# Altium schematic sheet Real Time Clock.SchDoc
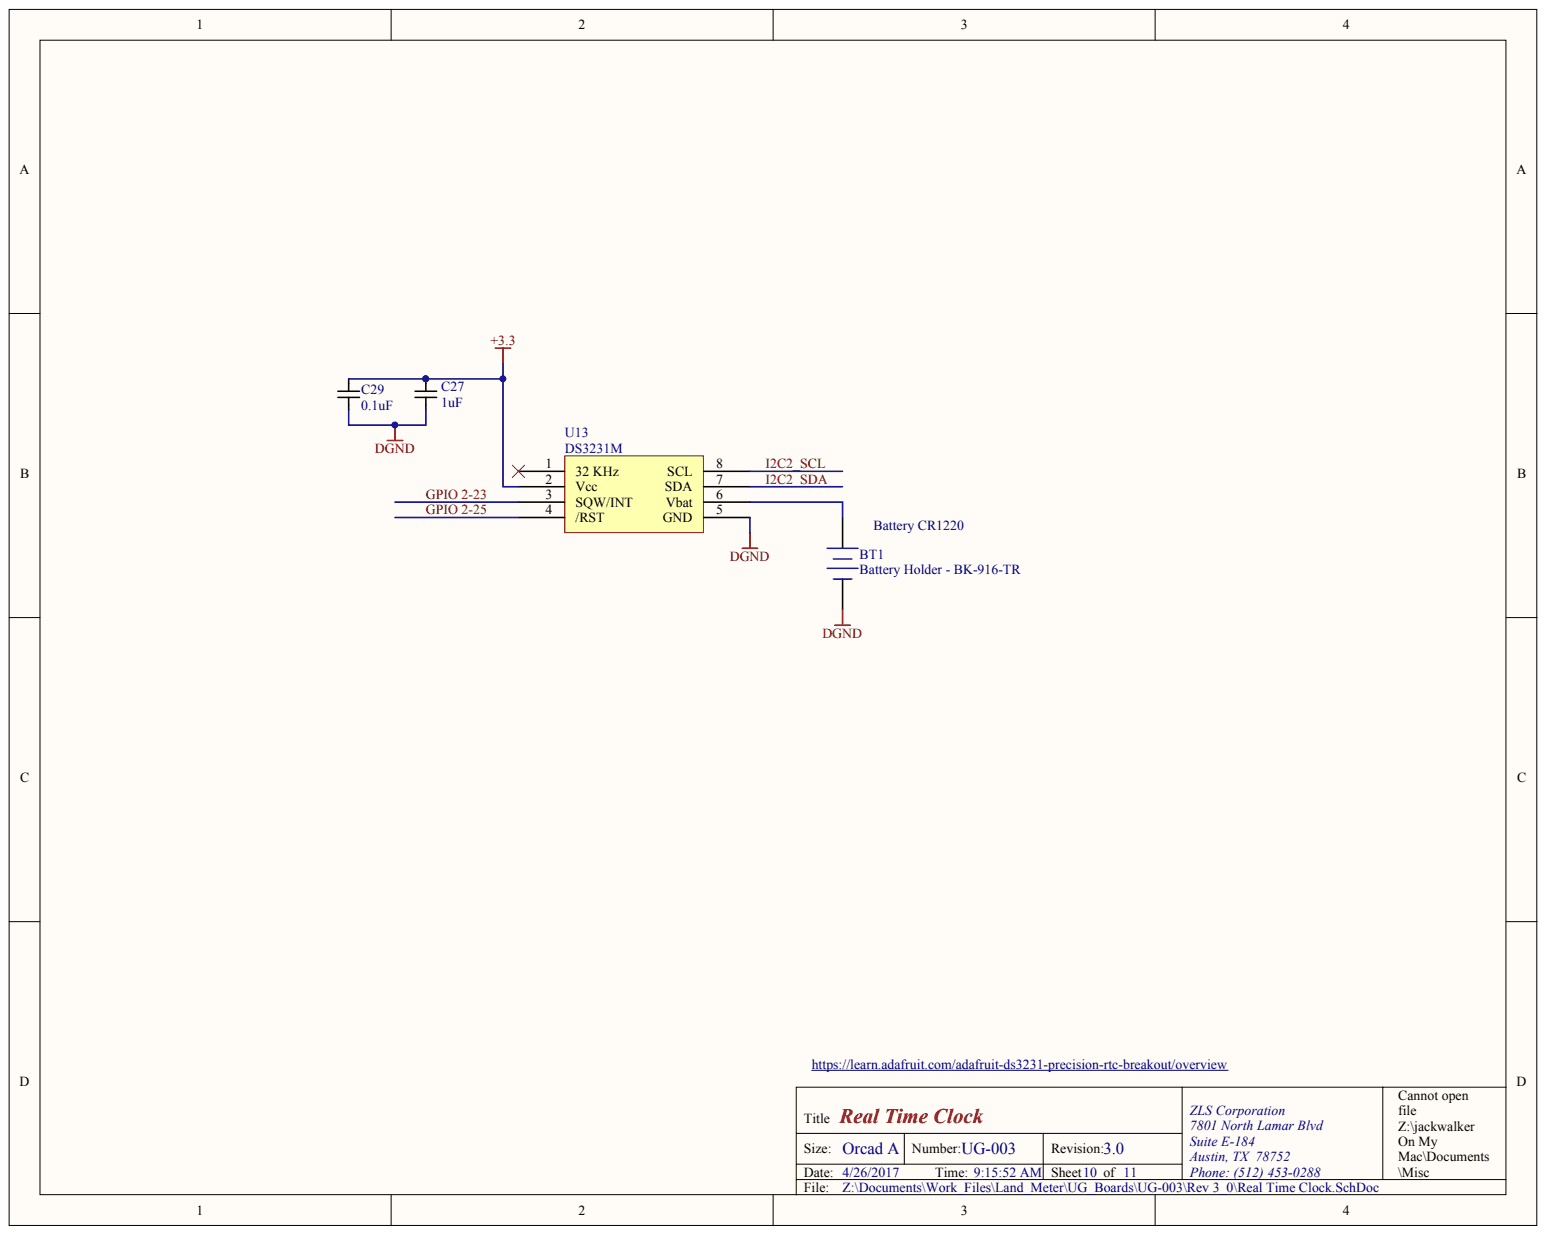
[diagram p0: Real Time Clock.SchDoc]

## Parts
BT1  Battery Holder - BK-916-TR
C27  1uF
C29  0.1uF
U13  DS3231M

## Nets
+3.3: C27.1 C29.1 U13.2(Vcc)
DGND: BT1.2 C27.2 C29.2 U13.5(GND)
GPIO 2-23: U13.3(SQW/INT)
GPIO 2-25: U13.4(/RST)
I2C2_SCL: U13.8(SCL)
I2C2_SDA: U13.7(SDA)
NetBT1_1: BT1.1 U13.6(Vbat)

Not connected: U13 1(32_KHz)

## Library data of the parts
BT1 = (no symbol name): Manufacturer 1: MPD (Memory Protection Devices); Description 1: CR1220, 1225 BATTERY RETAINER W/; Category 1: Battery Products; Battery Type, Function: Coin Cell, Retainer; Style: Retainer; Battery Cell Size: Coin, 12.0mm; Number of Cells: 1; Battery Series: 1216, 1220, 1225; Supplier 1: Digi-Key; Supplier Part Number 1: BK-916-CT-ND; Manufacturer Part Number 1: BK-916-TR; RoHS 1: RoHS Compliant; Stock 1: 7084; Pricing 1: 1=0.49, 10=0.449, 100=0.408, 500=0.357 (USD); Part Status: Active; Mounting Type: PCB, Surface Mount; Termination Style: SMD (SMT) Tab; Height Above Board: 0.551" (14.00mm); Operating Temperature: -; ComponentLink1URL: http://www.memoryprotectiondevices.com/datasheets/BK-916-datasheet.pdf; ComponentLink1Description: http://www.memoryprotectiondevices.com/datasheets/BK-916-datasheet.pdf
C27 = (no symbol name): Manufacturer 1: Murata Electronics North America; Manufacturer Part Number 1: GRM21BR71E105KA99L; Description 1: CAP CER 1UF 25V X7R 0805; Category 1: Capacitors; Capacitance: 1µF; Tolerance: ±10%; Voltage - Rated: 25V; Temperature Coefficient: X7R; Supplier 1: Digi-Key; Supplier Part Number 1: 490-6484-1-ND; RoHS 1: RoHS Compliant; Stock 1: 110166; Pricing 1: 1=0.14, 10=0.099, 25=0.0724, 50=0.0564, 100=0.0468, 250=0.03872, 500=0.0328, 1000=0.02715 (USD); Packaging: Cut Tape (CT); Part Status: Active; Operating Temperature: -55°C ~ 125°C; Features: -; Ratings: -; Applications: General Purpose; Mounting Type: Surface Mount, MLCC; Package / Case: 0805 (2012 Metric); Size / Dimension: 0.079" L x 0.049" W (2.00mm x 1.25mm); Height - Seated (Max): -; Thickness (Max): 0.053" (1.35mm); Lead Spacing: -; Lead Style: -; ComponentLink1URL: http://www.murata.com/~/media/webrenewal/support/library/catalog/products/capacitor/mlcc/c02e.ashx; ComponentLink1Description: http://www.murata.com/~/media/webrenewal/support/library/catalog/products/capacitor/mlcc/c02e.ashx
C29 = (no symbol name): Manufacturer 1: Murata Electronics North America; Manufacturer Part Number 1: GRM21BR71E104KA01L; Description 1: CAP CER 0.1UF 25V X7R 0805; Category 1: Capacitors; Capacitance: 0.1µF; Tolerance: ±10%; Voltage - Rated: 25V; Temperature Coefficient: X7R; Supplier 1: Digi-Key; Supplier Part Number 1: 490-1673-1-ND; RoHS 1: RoHS Compliant; Stock 1: 897437; Pricing 1: 1=0.2, 10=0.145, 25=0.106, 50=0.0824, 100=0.0683, 250=0.05652, 500=0.04788, 1000=0.03964 (USD); Packaging: Cut Tape (CT); Part Status: Active; Operating Temperature: -55°C ~ 125°C; Features: -; Ratings: -; Applications: General Purpose; Mounting Type: Surface Mount, MLCC; Package / Case: 0805 (2012 Metric); Size / Dimension: 0.079" L x 0.049" W (2.00mm x 1.25mm); Height - Seated (Max): -; Thickness (Max): 0.053" (1.35mm); Lead Spacing: -; Lead Style: -; ComponentLink1URL: http://www.murata.com/~/media/webrenewal/support/library/catalog/products/capacitor/mlcc/c02e.ashx; ComponentLink1Description: http://www.murata.com/~/media/webrenewal/support/library/catalog/products/capacitor/mlcc/c02e.ashx
U13 = (no symbol name): Manufacturer 1: Maxim Integrated; Manufacturer Part Number 1: DS3231MZ/V+; Description 1: IC RTC CLK/CALENDAR I2C 8-SOIC; Category 1: Integrated Circuits (ICs); Time Format: HH:MM:SS (12/24 hr); Date Format: YY-MM-DD-dd; Interface: I²C, 2-Wire Serial; Voltage - Supply: 2.3 V ~ 5.5 V; Current - Timekeeping (Max): 130µA ~ 200µA @ 3.63V ~ 5.5V; Supplier 1: Digi-Key; Supplier Part Number 1: DS3231MZ/V+-ND; RoHS 1: RoHS Compliant; Stock 1: 1340; Pricing 1: 1=6.46, 10=6.14, 25=5.06, 50=4.81, 250=4.65, 1000=3.98002 (USD); Packaging: Tube; Part Status: Active; Type: Clock/Calendar; Features: Alarm, Leap Year, Square Wave Output; Memory Size: -; Voltage - Supply, Battery: 2.3 V ~ 5.5 V; Operating Temperature: -40°C ~ 85°C; Mounting Type: Surface Mount; Package / Case: 8-SOIC (0.154", 3.90mm Width); Supplier Device Package: 8-SOIC; ComponentLink1URL: http://datasheets.maximintegrated.com/en/ds/DS3231M.pdf; ComponentLink1Description: http://datasheets.maximintegrated.com/en/ds/DS3231M.pdf
